# Altium schematic sheet LNG加气站数传.SchDoc(LNG加气站数传)
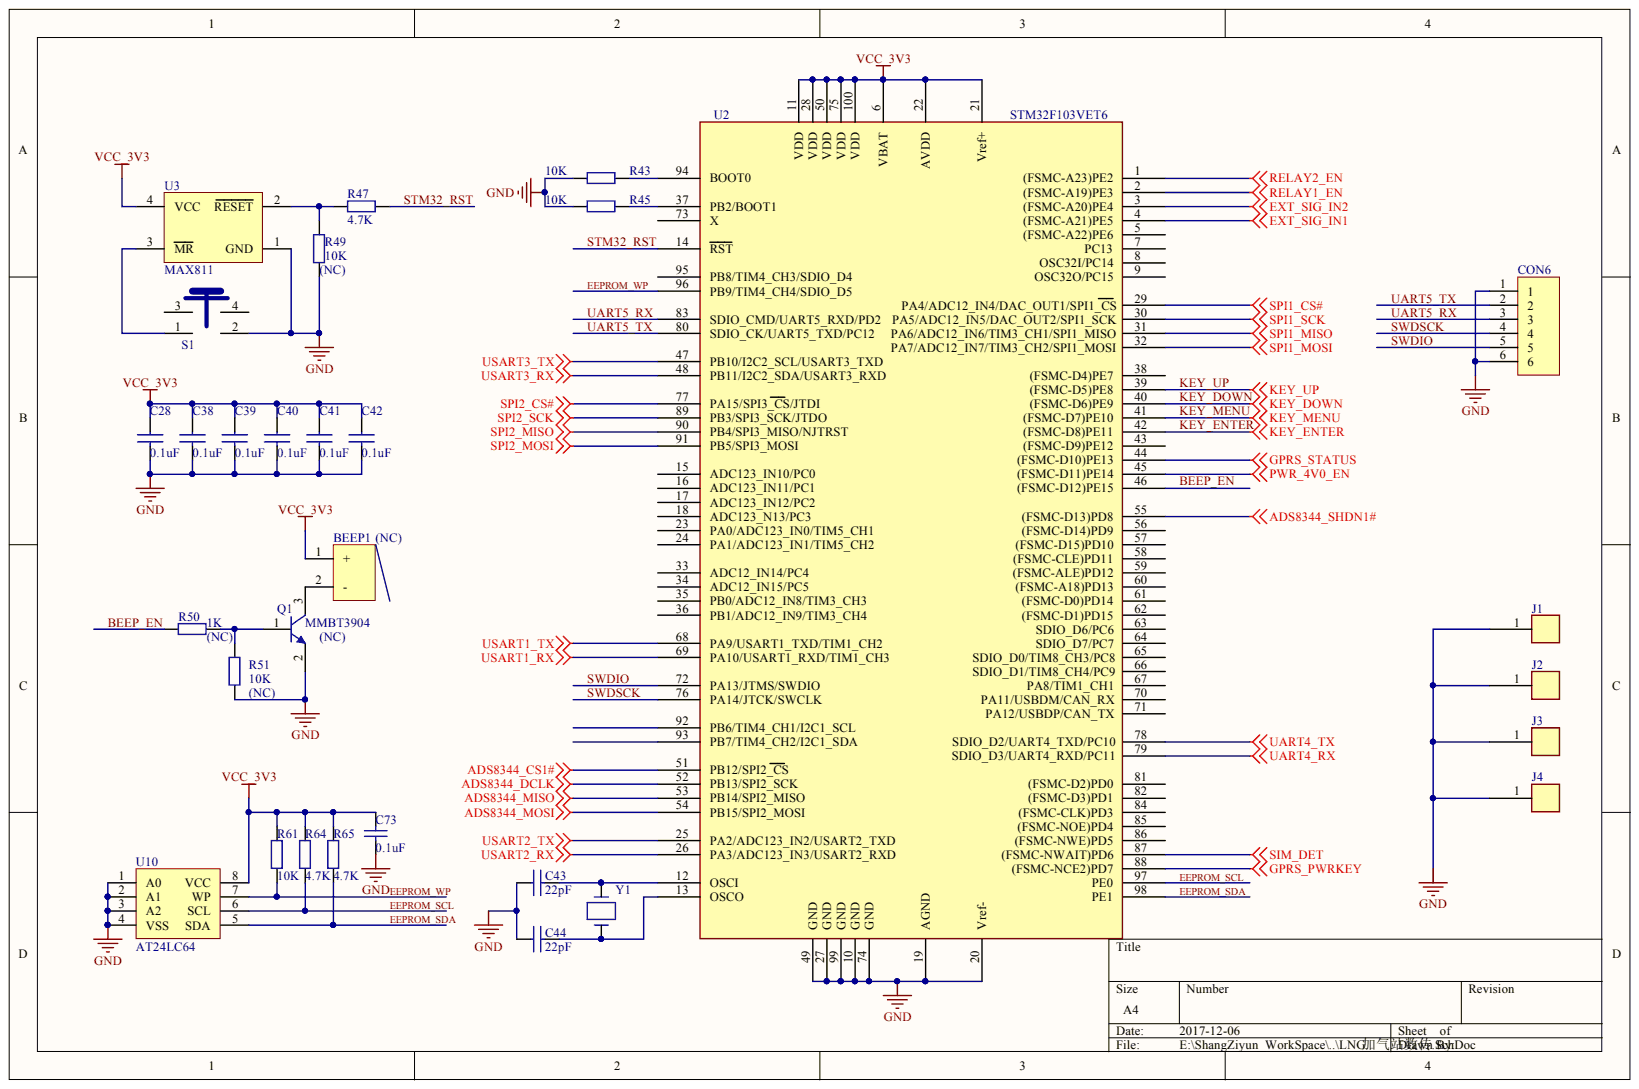
[diagram p0: LNG加气站数传.SchDoc]

## Parts
BEEP1  Define: (NC)  [BEEP_9mm]  (hidden comment: Beep)
C28  value 0.1uF  [0603C]  (hidden comment: CAP NP)
C38  value 0.1uF  [0603C]  (hidden comment: CAP NP)
C39  value 0.1uF  [0603C]  (hidden comment: CAP NP)
C40  value 0.1uF  [0603C]  (hidden comment: CAP NP)
C41  value 0.1uF  [0603C]  (hidden comment: CAP NP)
C42  value 0.1uF  [0603C]  (hidden comment: CAP NP)
C43  value 22pF  [0603C]  (hidden comment: CAP NP)
C44  value 22pF  [0603C]  (hidden comment: CAP NP)
C73  value 0.1uF  [0603C]  (hidden comment: Capacitor)
CON6  CONNECTOR_6PIN  [HEADER2.54-6P]  (value not drawn)
J1  CON1  [MHT-3mm]  (value not drawn)
J2  CON1  [MHT-3mm]  (value not drawn)
J3  CON1  [MHT-3mm]  (value not drawn)
J4  CON1  [MHT-3mm]  (value not drawn)
Q1  MMBT3904  Define: (NC)  [SOT23]
R43  value 10K  [L0603]  (hidden comment: Resistor)
R45  value 10K  [L0603]  (hidden comment: Resistor)
R47  value 4.7K  [L0603]  (hidden comment: Resistor)
R49  value 10K  Define: (NC)  [L0603]  (hidden comment: Resistor)
R50  value 1K  Define: (NC)  [L0603]  (hidden comment: Resistor)
R51  value 10K  Define: (NC)  [L0603]  (hidden comment: Resistor)
R61  value 10K  [L0603]  (hidden comment: Resistor)
R64  value 4.7K  [L0603]  (hidden comment: Resistor)
R65  value 4.7K  [L0603]  (hidden comment: Resistor)
S1  KEY  [6*6*6]  (value not drawn)
U2  STM32F103VET6  [LQFP100]
U3  MAX811  [SOD143]
U10  AT24LC64  [SOP8]
Y1  CRYSTAL_0  [ABM7]  (value not drawn)

## Nets
ADS8344_CS1#: U2.51(PB12/SPI2_CS)
ADS8344_DCLK: U2.52(PB13/SPI2_SCK)
ADS8344_MISO: U2.53(PB14/SPI2_MISO)
ADS8344_MOSI: U2.54(PB15/SPI2_MOSI)
ADS8344_SHDN1#: U2.55((FSMC-D13)PD8)
EXT_SIG_IN1: U2.4((FSMC-A21)PE5)
EXT_SIG_IN2: U2.3((FSMC-A20)PE4)
GND: C28.2 C38.2 C39.2 C40.2 C41.2 C42.2 C43.1 C44.1 C73.2 CON6.1 CON6.6 J1.1 J2.1 J3.1 J4.1 Q1.2 R43.1 R45.1 R49.1 R51.2 S1.2 U2.10(GND) U2.19(AGND) U2.20(Vref-) U2.27(GND) U2.49(GND) U2.74(GND) U2.99(GND) U3.1(GND) U10.1(A0) U10.2(A1) U10.3(A2) U10.4(VSS)
GPRS_PWRKEY: U2.88((FSMC-NCE2)PD7)
GPRS_STATUS: U2.44((FSMC-D10)PE13)
PWR_4V0_EN: U2.45((FSMC-D11)PE14)
RELAY1_EN: U2.2((FSMC-A19)PE3)
RELAY2_EN: U2.1((FSMC-A23)PE2)
SIM_DET: U2.87((FSMC-NWAIT)PD6)
SPI1_CS#: U2.29(PA4/ADC12_IN4/DAC_OUT1/SPI1_CS)
SPI1_MISO: U2.31(PA6/ADC12_IN6/TIM3_CH1/SPI1_MISO)
SPI1_MOSI: U2.32(PA7/ADC12_IN7/TIM3_CH2/SPI1_MOSI)
SPI1_SCK: U2.30(PA5/ADC12_IN5/DAC_OUT2/SPI1_SCK)
SPI2_CS#: U2.77(PA15/SPI3_CS/JTDI)
SPI2_MISO: U2.90(PB4/SPI3_MISO/NJTRST)
SPI2_MOSI: U2.91(PB5/SPI3_MOSI)
SPI2_SCK: U2.89(PB3/SPI3_SCK/JTDO)
UART4_RX: U2.79(SDIO_D3/UART4_RXD/PC11)
UART4_TX: U2.78(SDIO_D2/UART4_TXD/PC10)
USART1_RX: U2.69(PA10/USART1_RXD/TIM1_CH3)
USART1_TX: U2.68(PA9/USART1_TXD/TIM1_CH2)
USART2_RX: U2.26(PA3/ADC123_IN3/USART2_RXD)
USART2_TX: U2.25(PA2/ADC123_IN2/USART2_TXD)
USART3_RX: U2.48(PB11/I2C2_SDA/USART3_RXD)
USART3_TX: U2.47(PB10/I2C2_SCL/USART3_TXD)
VCC_3V3: BEEP1.1 C28.1 C38.1 C39.1 C40.1 C41.1 C42.1 C73.1 R61.1 R64.1 R65.1 U2.6(VBAT) U2.11(VDD) U2.21(Vref+) U2.22(AVDD) U2.28(VDD) U2.50(VDD) U2.75(VDD) U2.100(VDD) U3.4(VCC) U10.8(VCC)
BEEP_EN: R50.1 U2.46((FSMC-D12)PE15)
EEPROM_SCL: R64.2 U2.97(PE0) U10.6(SCL)
EEPROM_SDA: R65.2 U2.98(PE1) U10.5(SDA)
EEPROM_WP: R61.2 U2.96(PB9/TIM4_CH4/SDIO_D5) U10.7(WP)
KEY_DOWN: U2.40((FSMC-D6)PE9)
KEY_ENTER: U2.42((FSMC-D8)PE11)
KEY_MENU: U2.41((FSMC-D7)PE10)
KEY_UP: U2.39((FSMC-D5)PE8)
STM32_RST: R47.2 U2.14(RST)
SWDIO: CON6.5 U2.72(PA13/JTMS/SWDIO)
SWDSCK: CON6.4 U2.76(PA14/JTCK/SWCLK)
UART5_RX: CON6.3 U2.83(SDIO_CMD/UART5_RXD/PD2)
UART5_TX: CON6.2 U2.80(SDIO_CK/UART5_TXD/PC12)
NetBEEP1_2: BEEP1.2 Q1.3
NetC43_2: C43.2 U2.12(OSCI) Y1.1
NetC44_2: C44.2 U2.13(OSCO) Y1.2
NetQ1_1: Q1.1 R50.2 R51.1
NetR43_2: R43.2 U2.94(BOOT0)
NetR45_2: R45.2 U2.37(PB2/BOOT1)
NetR47_1: R47.1 R49.2 U3.2(RESET)
NetS1_1: S1.1 U3.3(MR)

Not connected: S1 3 4; U2 5((FSMC-A22)PE6) 7(PC13) 8(OSC32I/PC14) 9(OSC32O/PC15) 15(ADC123_IN10/PC0) 16(ADC123_IN11/PC1) 17(ADC123_IN12/PC2) 18(ADC123_N13/PC3) 23(PA0/ADC123_IN0/TIM5_CH1) 24(PA1/ADC123_IN1/TIM5_CH2) 33(ADC12_IN14/PC4) 34(ADC12_IN15/PC5) 35(PB0/ADC12_IN8/TIM3_CH3) 36(PB1/ADC12_IN9/TIM3_CH4) 38((FSMC-D4)PE7) 43((FSMC-D9)PE12) 56((FSMC-D14)PD9) 57((FSMC-D15)PD10) 58((FSMC-CLE)PD11) 59((FSMC-ALE)PD12) 60((FSMC-A18)PD13) 61((FSMC-D0)PD14) 62((FSMC-D1)PD15) 63(SDIO_D6/PC6) 64(SDIO_D7/PC7) 65(SDIO_D0/TIM8_CH3/PC8) 66(SDIO_D1/TIM8_CH4/PC9) 67(PA8/TIM1_CH1) 70(PA11/USBDM/CAN_RX) 71(PA12/USBDP/CAN_TX) 73(X) 81((FSMC-D2)PD0) 82((FSMC-D3)PD1) 84((FSMC-CLK)PD3) 85((FSMC-NOE)PD4) 86((FSMC-NWE)PD5) 92(PB6/TIM4_CH1/I2C1_SCL) 93(PB7/TIM4_CH2/I2C1_SDA) 95(PB8/TIM4_CH3/SDIO_D4)

## Library data of the parts
BEEP1 = Beep: Value: 3V有源蜂鸣器; Component Kind: Standard; Library Name: ZL0611.SCHLIB
C73 = Cap: Component Kind: Standard; Description: Capacitor; LatestRevisionDate: 17-Jul-2002; LatestRevisionNote: Re-released for DXP Platform.; Library Name: Miscellaneous Devices.IntLib; PackageDescription: Radial Cap, Thru-Hole; 2 Leads; 0.3 in Pin Spacing; PackageReference: RAD-0.3; Published: 8-Jun-2000; Signal Integrity: Cap; Simulation: CAP; Publisher: Altium Limited
CON6 = CONNECTOR_6PIN: Value: 2.54mm排针; Component Kind: Standard; Library Name: MyLib.SCHLIB
Q1 = NPN: Component Kind: Standard; Value: MMBT3904; Library Name: LNG.SCHLIB
R43 R45 R47 R49-R51 R61 R64 R65 = Res: Component Kind: Standard
  R47 R49-R51 R61 R64 R65: Library Name: Lib1.SCHLIB
  R43 R45: Library Name: LNG.SCHLIB
S1 = KEY: Value: 6*6*10卧式直插按钮; Component Kind: Standard; Library Name: Bio.SchLib
U10 = 24LC64: Component Kind: Standard; Library Name: MyLib.SCHLIB; Value: AT24LC64
Y1 = CRYSTAL_0: Value: 8M黑色陶瓷2脚无源晶体; Component Kind: Standard; Library Name: MAINBOARD_Library.SchLib
C28 C38-C44 = CAP NP: Component Kind: Standard
  C28 C39-C44: Library Name: MAINBOARD_Library.SchLib
J1-J4 = CON1: Component Kind: Standard; Library Name: MAINBOARD_Library.SchLib
U2 = STM32F103VET6: Component Kind: Standard; Library Name: MAINBOARD_Library.SchLib; Value: STM32F103VET6
U3 = MAX811: Component Kind: Standard; Value: MAX811; Library Name: LNG.SCHLIB
